# Altium schematic sheet Sheet_USB32.SchDoc
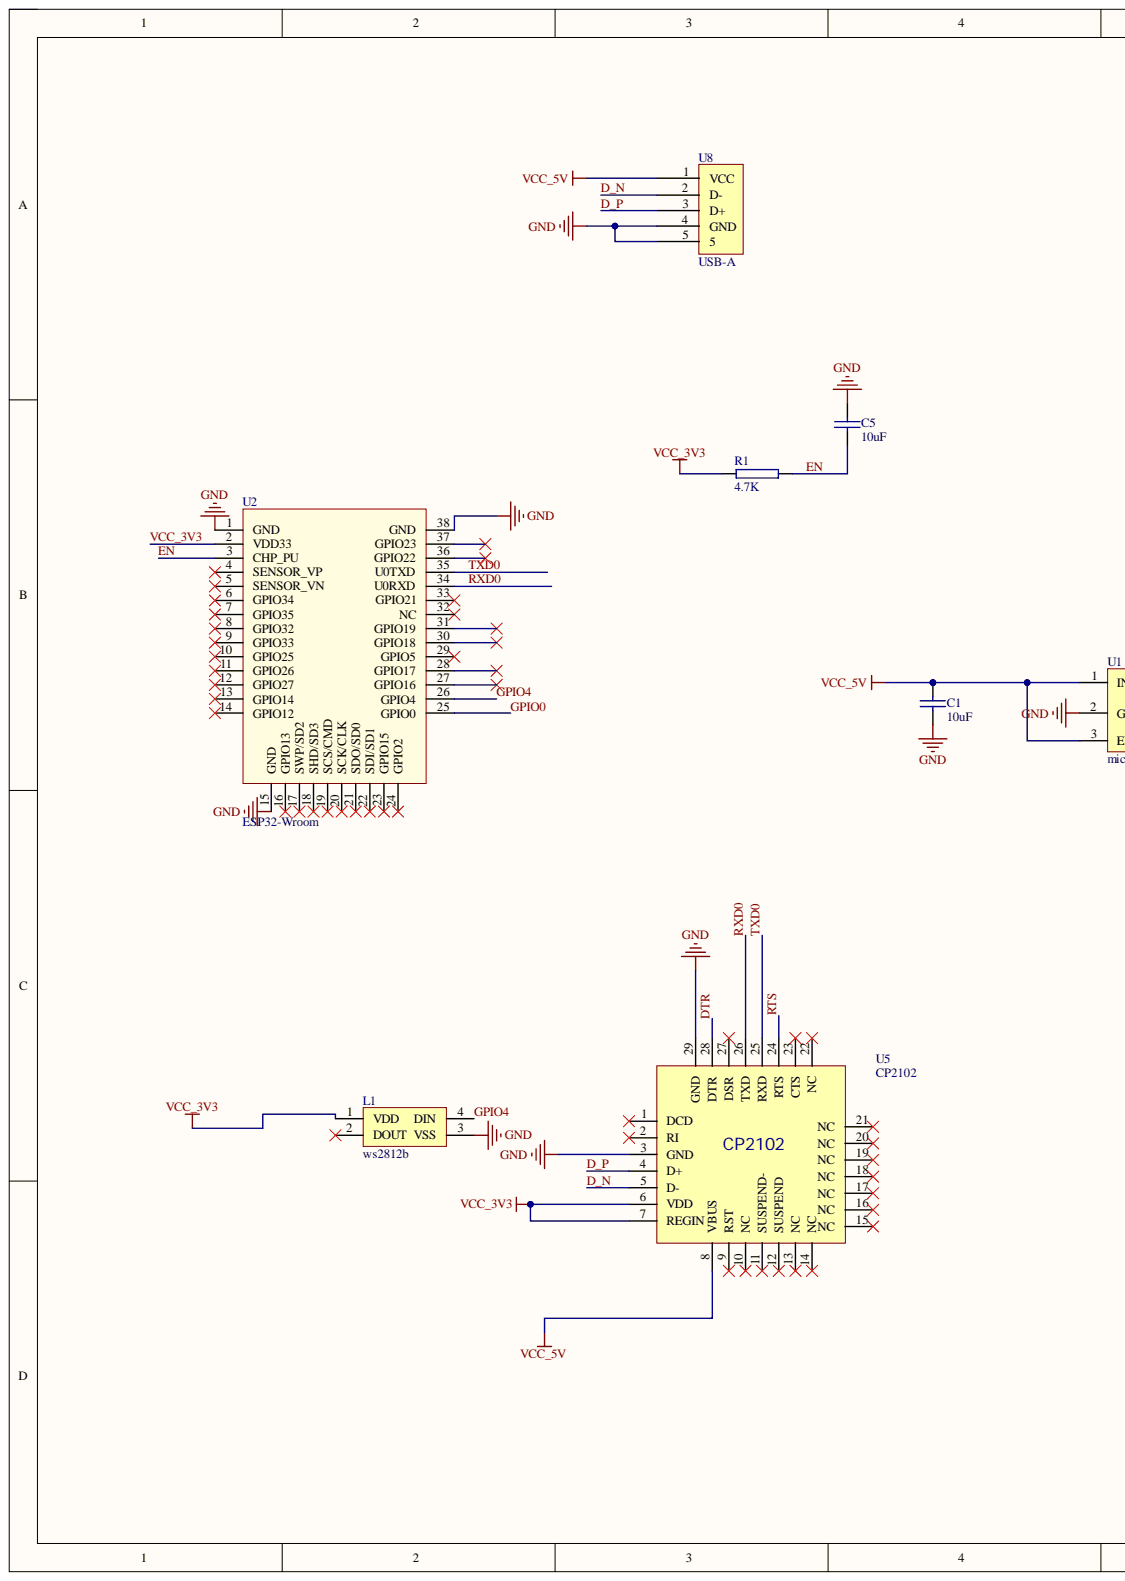
[diagram p0: Sheet_USB32.SchDoc, left]
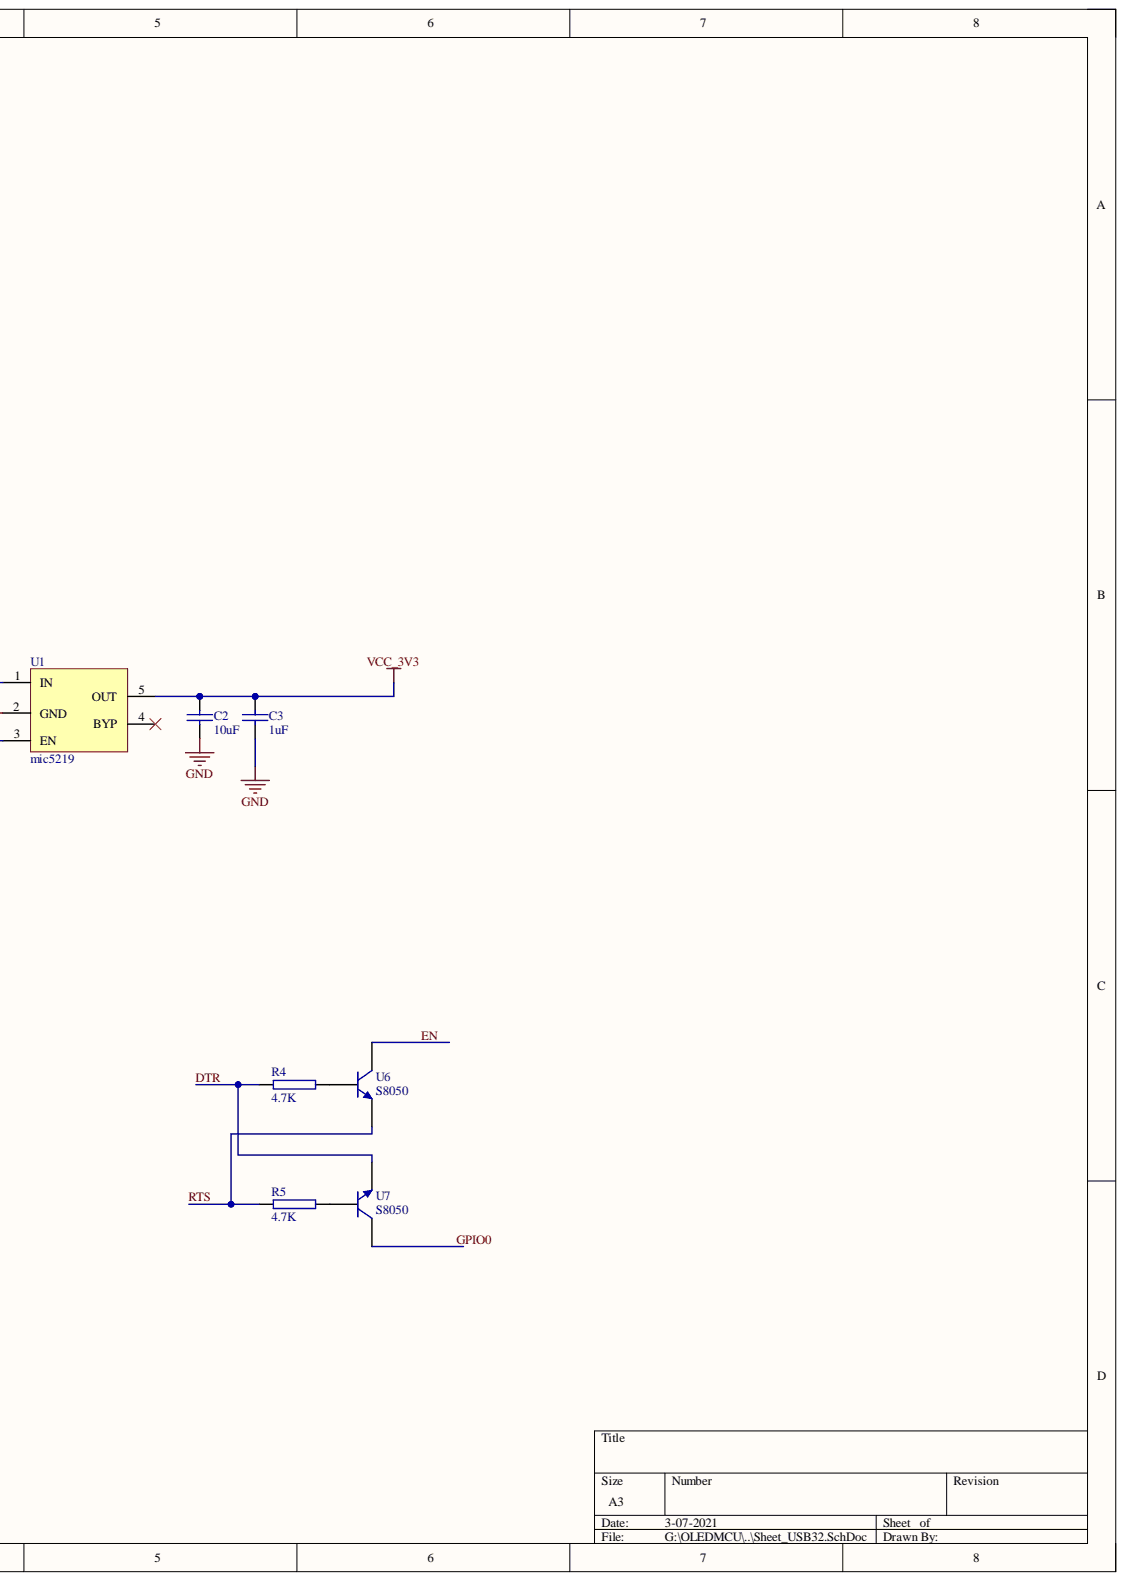
[diagram p1: Sheet_USB32.SchDoc, right]

## Parts
C1  10uF  [C0603]
C2  10uF  [C0603]
C3  1uF  [C0603]
C5  10uF  [C0603]
L1  ws2812b  [ws2812b]
R1  4.7K  [R0603]
R4  4.7K  [R0603]
R5  4.7K  [R0603]
U1  mic5219  [mic5219]
U2  ESP32-Wroom  [ESP32s]
U5  CP2102  [cp2102]
U6  S8050  [s8050]
U7  S8050  [s8050]
U8  USB-A  [USB-A]

## Nets
GND: C1.1 C2.1 C3.2 C5.2 L1.3(VSS) U1.2(GND) U2.1(GND) U2.15(GND) U2.38(GND) U5.3(GND) U5.29(GND) U8.4(GND) U8.5
VCC_5V: C1.2 U1.1(IN) U1.3(EN) U5.8(VBUS) U8.1(VCC)
D_N: U5.5(D-) U8.2(D-)
D_P: U5.4(D+) U8.3(D+)
DTR: R4.1 U5.28(DTR) U7.2
EN: C5.1 R1.2 U2.3(CHP_PU) U6.3
GPIO0: U2.25(GPIO0) U7.3
GPIO4: L1.4(DIN) U2.26(GPIO4)
RTS: R5.1 U5.24(RTS) U6.2
RXD0: U2.34(U0RXD) U5.26(TXD)
TXD0: U2.35(U0TXD) U5.25(RXD)
VCC_3V3: C2.2 C3.1 L1.1(VDD) R1.1 U1.5(OUT) U2.2(VDD33) U5.6(VDD) U5.7(REGIN)
NetR4_2: R4.2 U6.1
NetR5_2: R5.2 U7.1

Not connected: L1 2(DOUT); U1 4(BYP); U2 4(SENSOR_VP) 5(SENSOR_VN) 6(GPIO34) 7(GPIO35) 8(GPIO32) 9(GPIO33) 10(GPIO25) 11(GPIO26) 12(GPIO27) 13(GPIO14) 14(GPIO12) 16(GPIO13) 17(SWP/SD2) 18(SHD/SD3) 19(SCS/CMD) 20(SCK/CLK) 21(SDO/SD0) 22(SDI/SD1) 23(GPIO15) 24(GPIO2) 27(GPIO16) 28(GPIO17) 29(GPIO5) 30(GPIO18) 31(GPIO19) 32(NC) 33(GPIO21) 36(GPIO22) 37(GPIO23); U5 1(DCD) 2(RI) 9(RST) 10(NC) 11(SUSPEND-) 12(SUSPEND) 13(NC) 14(NC) 15(NC) 16(NC) 17(NC) 18(NC) 19(NC) 20(NC) 21(NC) 22(NC) 23(CTS) 27(DSR)

## Library data of the parts
C1-C3 C5 = C0603: 贴片电容; Component Kind: Standard; Library Name: Schlib_OLEDMCU.SchLib
L1 = ws2812b: RGB灯珠; Component Kind: Standard; Library Name: Schlib_OLEDMCU.SchLib
R1 R4 R5 = R0603: 贴片电阻; Component Kind: Standard; Library Name: Schlib_OLEDMCU.SchLib
U1 = Mic5219: 5V转3.3V; Component Kind: Standard; Library Name: Schlib_OLEDMCU.SchLib
U2 = ESP32: WIFI&Bluetooth; Component Kind: Standard; Library Name: Schlib_OLEDMCU.SchLib
U5 = CP2102: USB转串口芯片; Component Kind: Standard; Library Name: Schlib_OLEDMCU.SchLib
U6 U7 = S8050: 三极管; Component Kind: Standard; Library Name: Schlib_OLEDMCU.SchLib
U8 = USB-A: USB公头; Component Kind: Standard; Library Name: Schlib_OLEDMCU.SchLib
